# Altium schematic sheet EVK-NORA-W4_SCHEM_0003_USBhub_FTDI.SchDoc
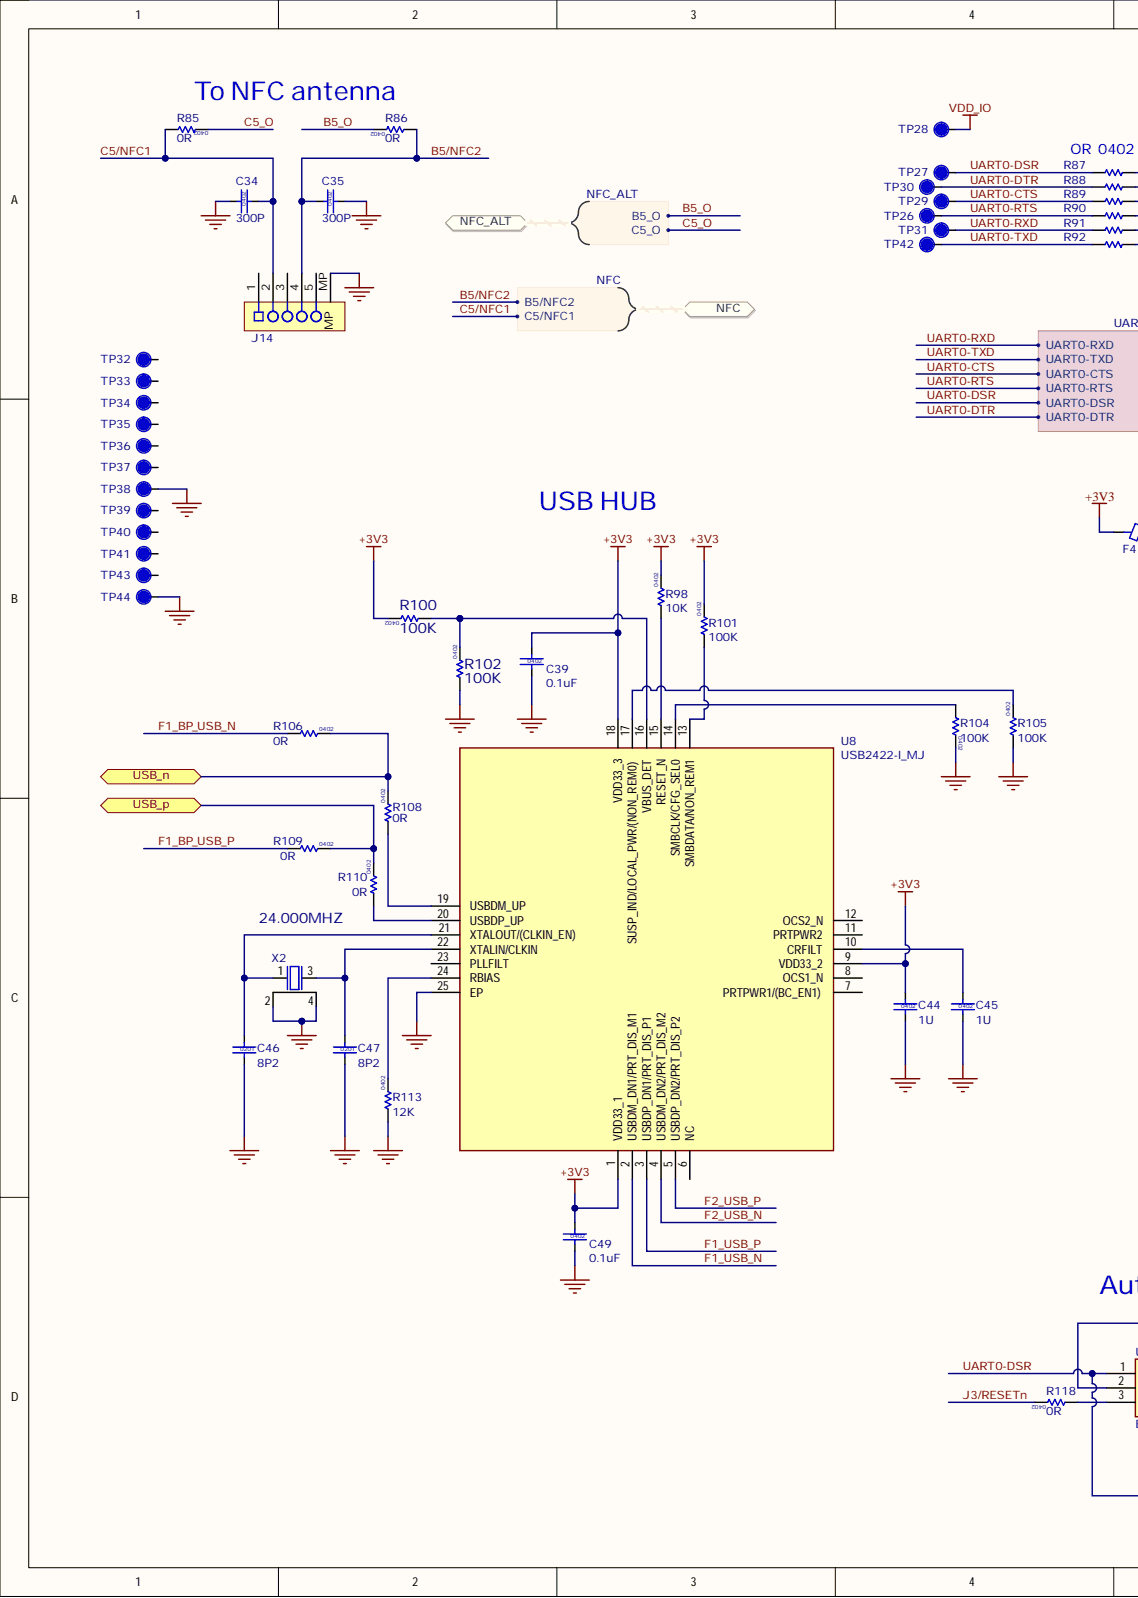
[diagram p0: EVK-NORA-W4_SCHEM_0003_USBhub_FTDI.SchDoc, left]
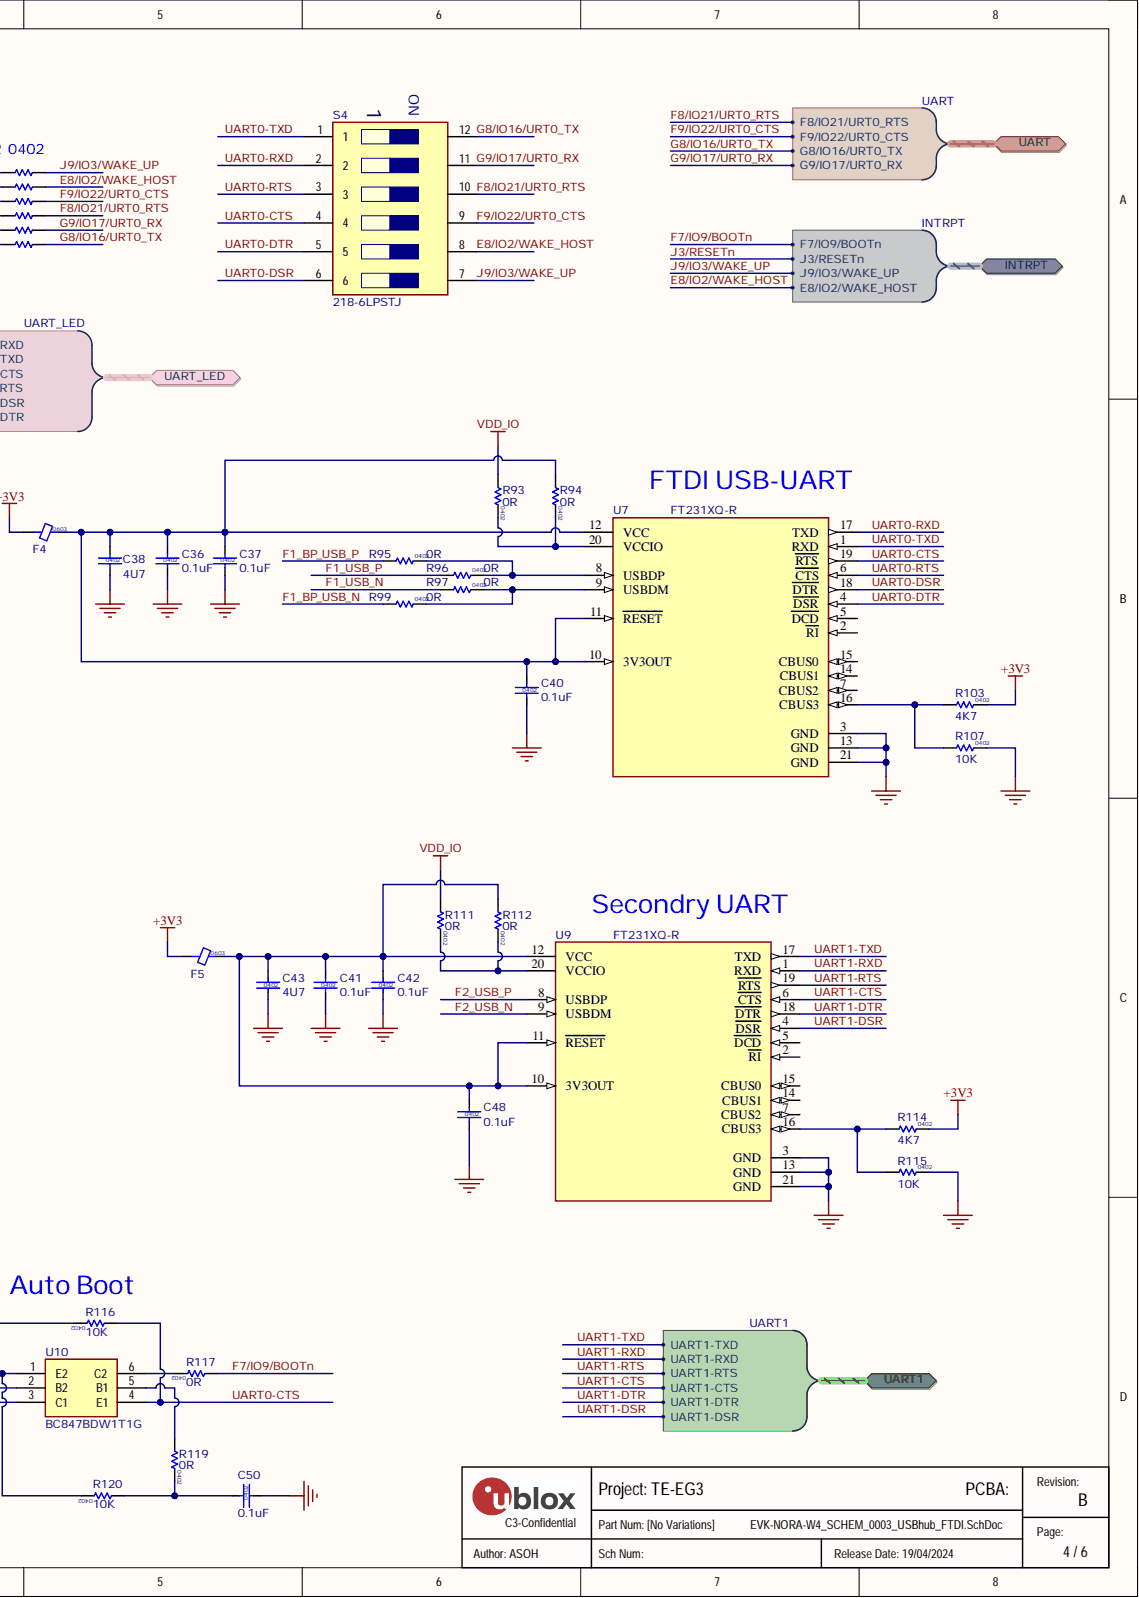
[diagram p1: EVK-NORA-W4_SCHEM_0003_USBhub_FTDI.SchDoc, right]

## Parts
C34  value 300P  Size: 0402  [Cap 0402 HD]  (hidden comment: UBXH01-0000594300P)
C35  value 300P  Size: 0402  [Cap 0402 HD]  (hidden comment: UBXH01-0000594300P)
C36  value 0.1uF  Size: 0402  [Cap 0402 HD]  (hidden comment: 120-000160.1uF)
C37  value 0.1uF  Size: 0402  [Cap 0402 HD]  (hidden comment: 120-000160.1uF)
C38  value 4U7  Size: 0402  [Cap 0402 HD]  (hidden comment: UBXH01-00002434U7)
C39  value 0.1uF  Size: 0402  [Cap 0402 HD]  (hidden comment: 120-000160.1uF)
C40  value 0.1uF  Size: 0402  [Cap 0402 HD]  (hidden comment: 120-000160.1uF)
C41  value 0.1uF  Size: 0402  [Cap 0402 HD]  (hidden comment: 120-000160.1uF)
C42  value 0.1uF  Size: 0402  [Cap 0402 HD]  (hidden comment: 120-000160.1uF)
C43  value 4U7  Size: 0402  [Cap 0402 HD]  (hidden comment: UBXH01-00002434U7)
C44  value 1U  Size: 0402  [Cap 0402 HD]  (hidden comment: UBXH01-00002481U)
C45  value 1U  Size: 0402  [Cap 0402 HD]  (hidden comment: UBXH01-00002481U)
C46  value 8P2  Size: 0201  [Cap 0201 HD]  (hidden comment: UBXH01-00130028P2)
C47  value 8P2  Size: 0201  [Cap 0201 HD]  (hidden comment: UBXH01-00130028P2)
C48  value 0.1uF  Size: 0402  [Cap 0402 HD]  (hidden comment: 120-000160.1uF)
C49  value 0.1uF  Size: 0402  [Cap 0402 HD]  (hidden comment: 120-000160.1uF)
C50  value 0.1uF  Size: 0402  [Cap 0402 HD]  (hidden comment: 120-000160.1uF)
F4  Size: 0603  [IND_0603_1750Lx950Wx1050H_600x800Pad_800G_FLX]  (hidden comment: UBXH08-0000001)
F5  Size: 0603  [IND_0603_1750Lx950Wx1050H_600x800Pad_800G_FLX]  (hidden comment: UBXH08-0000001)
J14  UBXH04-0000798  [AVX/Kyocera - 046284005001846]  (value not drawn)
R85  value 0R  Size: 0402  [RES 0402 HD]  (hidden comment: UBXH18-00030020R)
R86  value 0R  Size: 0402  [RES 0402 HD]  (hidden comment: UBXH18-00030020R)
R87  Size: 0402  [RES 0402 HD]  (hidden comment: UBXH18-00030020R)
R88  UBXH18-00030020R  [RES 0402 HD]  (value not drawn)
R89  UBXH18-00030020R  [RES 0402 HD]  (value not drawn)
R90  UBXH18-00030020R  [RES 0402 HD]  (value not drawn)
R91  UBXH18-00030020R  [RES 0402 HD]  (value not drawn)
R92  UBXH18-00030020R  [RES 0402 HD]  (value not drawn)
R93  value 0R  Size: 0402  [RES 0402 HD]  (hidden comment: UBXH18-00030020R)
R94  value 0R  Size: 0402  [RES 0402 HD]  (hidden comment: UBXH18-00030020R)
R95  value 0R  Size: 0402  [RES 0402 HD]  (hidden comment: UBXH18-00030020R)
R96  value 0R  Size: 0402  [RES 0402 HD]  (hidden comment: UBXH18-00030020R)
R97  value 0R  Size: 0402  [RES 0402 HD]  (hidden comment: UBXH18-00030020R)
R98  value 10K  Size: 0402  [RES 0402 HD]  (hidden comment: UBXH18-000006510K)
R99  value 0R  Size: 0402  [RES 0402 HD]  (hidden comment: UBXH18-00030020R)
R100  value 100K  Size: 0402  [RES 0402 HD]  (hidden comment: UBXH18-0000005100K)
R101  value 100K  Size: 0402  [RES 0402 HD]  (hidden comment: UBXH18-0000005100K)
R102  value 100K  Size: 0402  [RES 0402 HD]  (hidden comment: UBXH18-0000005100K)
R103  value 4K7  Size: 0402  [RES_0402_1100Lx600Wx400H_500x600Pad_400G_FLX]  (hidden comment: UBXH18-00080654K7)
R104  value 100K  Size: 0402  [RES 0402 HD]  (hidden comment: UBXH18-0000005100K)
R105  value 100K  Size: 0402  [RES 0402 HD]  (hidden comment: UBXH18-0000005100K)
R106  value 0R  Size: 0402  [RES 0402 HD]  (hidden comment: UBXH18-00030020R)
R107  value 10K  Size: 0402  [RES_0402_1100Lx600Wx400H_500x600Pad_400G_FLX]  (hidden comment: UBXH18-000807310K)
R108  value 0R  Size: 0402  [RES 0402 HD]  (hidden comment: UBXH18-00030020R)
R109  value 0R  Size: 0402  [RES 0402 HD]  (hidden comment: UBXH18-00030020R)
R110  value 0R  Size: 0402  [RES 0402 HD]  (hidden comment: UBXH18-00030020R)
R111  value 0R  Size: 0402  [RES 0402 HD]  (hidden comment: UBXH18-00030020R)
R112  value 0R  Size: 0402  [RES 0402 HD]  (hidden comment: UBXH18-00030020R)
R113  value 12K  Size: 0402  [RES_0402_1100Lx600Wx400H_500x600Pad_400G_FLX]  (hidden comment: UBXH18-000013312K)
R114  value 4K7  Size: 0402  [RES_0402_1100Lx600Wx400H_500x600Pad_400G_FLX]  (hidden comment: UBXH18-00080654K7)
R115  value 10K  Size: 0402  [RES_0402_1100Lx600Wx400H_500x600Pad_400G_FLX]  (hidden comment: UBXH18-000807310K)
R116  value 10K  Size: 0402  [RES 0402 HD]  (hidden comment: UBXH18-000006510K)
R117  value 0R  Size: 0402  [RES 0402 HD]  (hidden comment: UBXH18-00030020R)
R118  value 0R  Size: 0402  [RES 0402 HD]  (hidden comment: UBXH18-00030020R)
R119  value 0R  Size: 0402  [RES 0402 HD]  (hidden comment: UBXH18-00030020R)
R120  value 10K  Size: 0402  [RES 0402 HD]  (hidden comment: UBXH18-000006510K)
S4  218-6LPSTJ  [2186LPSTJ]
TP26  TP  [TP10R]  (no BOM)
TP27  TP  [TP10R]  (no BOM)
TP28  TP  [TP10R]  (no BOM)
TP29  TP  [TP10R]  (no BOM)
TP30  TP  [TP10R]  (no BOM)
TP31  TP  [TP10R]  (no BOM)
TP32  TP  [TP10R]  (no BOM)
TP33  TP  [TP10R]  (no BOM)
TP34  TP  [TP10R]  (no BOM)
TP35  TP  [TP10R]  (no BOM)
TP36  TP  [TP10R]  (no BOM)
TP37  TP  [TP10R]  (no BOM)
TP38  TP  [TP10R]  (no BOM)
TP39  TP  [TP10R]  (no BOM)
TP40  TP  [TP10R]  (no BOM)
TP41  TP  [TP10R]  (no BOM)
TP42  TP  [TP10R]  (no BOM)
TP43  TP  [TP10R]  (no BOM)
TP44  TP  [TP10R]  (no BOM)
U7  FT231XQ-R  [QFN_5x5_FT231XQ]
U8  USB2422-I_MJ  [QFN50P400X400X100-25N-D]
U9  FT231XQ-R  [QFN_5x5_FT231XQ]
U10  BC847BDW1T1G  [SOT65P210X110-6N]
X2  value 24.000MHZ  [XTAL_2016_HD]  (hidden comment: UBXH21-0000066)

## Ports
INTRPT, INTRPT.E8/IO2/WAKE_HOST, INTRPT.F7/IO9/BOOTn, INTRPT.J3/RESETn, INTRPT.J9/IO3/WAKE_UP, NFC, NFC.B5/NFC2, NFC.C5/NFC1, NFC_ALT, NFC_ALT.B5_O, NFC_ALT.C5_O, UART, UART1, UART1.UART1-CTS, UART1.UART1-DSR, UART1.UART1-DTR, UART1.UART1-RTS, UART1.UART1-RXD, UART1.UART1-TXD, UART.F8/IO21/URT0_RTS, UART.F9/IO22/URT0_CTS, UART.G8/IO16/URT0_TX, UART.G9/IO17/URT0_RX, UART_LED, UART_LED.UART0-CTS, UART_LED.UART0-DSR, UART_LED.UART0-DTR, UART_LED.UART0-RTS, UART_LED.UART0-RXD, UART_LED.UART0-TXD, USB_n, USB_p

## Nets
+3V3: C39.1 C44.1 C49.1 F4.1 F5.1 R98.2 R100.2 R101.2 R103.2 R114.2 U8.1(VDD33_1) U8.9(VDD33_2) U8.18(VDD33_3)
GND: C34.1 C35.2 C36.2 C37.2 C38.2 C39.2 C40.2 C41.2 C42.2 C43.2 C44.2 C45.2 C46.2 C47.2 C48.2 C49.2 C50.2 J14.MP R102.1 R104.2 R105.1 R107.2 R113.1 R115.2 TP38.1 TP44.1 U7.3(GND) U7.13(GND) U7.21(GND) U8.25(EP) U9.3(GND) U9.13(GND) U9.21(GND) X2.2 X2.4
VDD_IO: R93.1 R111.1 TP28.1
B5/NFC2: C35.1 J14.4 R86.1 ; port NFC
B5_O: R86.2 ; port NFC_ALT
C5/NFC1: C34.2 J14.2 R85.2 ; port NFC
C5_O: R85.1 ; port NFC_ALT
E8/IO2/WAKE_HOST: R88.2 S4.8 ; port INTRPT
F1_BP_USB_N: R99.1 R106.1
F1_BP_USB_P: R95.1 R109.1
F1_USB_N: R97.1 U8.2(USBDM_DN1/PRT_DIS_M1)
F1_USB_P: R96.1 U8.3(USBDP_DN1/PRT_DIS_P1)
F2_USB_N: U8.4(USBDM_DN2/PRT_DIS_M2) U9.9(USBDM)
F2_USB_P: U8.5(USBDP_DN2/PRT_DIS_P2) U9.8(USBDP)
F7/IO9/BOOTn: R117.1 ; port INTRPT
F8/IO21/URT0_RTS: R90.2 S4.10 ; port UART
F9/IO22/URT0_CTS: R89.2 S4.9 ; port UART
G8/IO16/URT0_TX: R92.2 S4.12 ; port UART
G9/IO17/URT0_RX: R91.2 S4.11 ; port UART
J3/RESETn: R118.2 ; port INTRPT
J9/IO3/WAKE_UP: R87.2 S4.7 ; port INTRPT
UART0-CTS: R89.1 R116.1 S4.4 TP29.1 U7.19(RTS) U10.4(E1) ; port UART_LED
UART0-DSR: R87.1 R120.2 S4.6 TP27.1 U7.18(DTR) U10.1(E2) ; port UART_LED
UART0-DTR: R88.1 S4.5 TP30.1 U7.4(DSR) ; port UART_LED
UART0-RTS: R90.1 S4.3 TP26.1 U7.6(CTS) ; port UART_LED
UART0-RXD: R91.1 S4.2 TP31.1 U7.17(TXD) ; port UART_LED
UART0-TXD: R92.1 S4.1 TP42.1 U7.1(RXD) ; port UART_LED
UART1-CTS: U9.6(CTS) ; port UART1
UART1-DSR: U9.4(DSR) ; port UART1
UART1-DTR: U9.18(DTR) ; port UART1
UART1-RTS: U9.19(RTS) ; port UART1
UART1-RXD: U9.1(RXD) ; port UART1
UART1-TXD: U9.17(TXD) ; port UART1
USB_n: R106.2 R108.2 ; port USB_n
USB_p: R109.2 R110.2 ; port USB_p
NetC36_1: C36.1 C37.1 C38.1 C40.1 F4.2 R94.1 U7.10(3V3OUT) U7.11(RESET) U7.12(VCC)
NetC41_1: C41.1 C42.1 C43.1 C48.1 F5.2 R112.1 U9.10(3V3OUT) U9.11(RESET) U9.12(VCC)
NetC45_1: C45.1 U8.10(CRFILT)
NetC46_1: C46.1 U8.21(XTALOUT/(CLKIN_EN)) X2.1
NetC47_1: C47.1 U8.22(XTALIN/CLKIN) X2.3
NetC50_1: C50.1 R119.2 R120.1
NetR93_2: R93.2 R94.2 U7.20(VCCIO)
NetR95_2: R95.2 R96.2 U7.8(USBDP)
NetR97_2: R97.2 R99.2 U7.9(USBDM)
NetR98_1: R98.1 U8.15(RESET_N)
NetR100_1: R100.1 R102.2 U8.16(VBUS_DET)
NetR101_1: R101.1 U8.13(SMBDATA/NON_REM1)
NetR103_1: R103.1 R107.1 U7.16(CBUS3)
NetR104_1: R104.1 U8.14(SMBCLK/CFG_SEL0)
NetR105_2: R105.2 U8.17(SUSP_IND/LOCAL_PWR/(NON_REM0))
NetR108_1: R108.1 U8.19(USBDM_UP)
NetR110_1: R110.1 U8.20(USBDP_UP)
NetR111_2: R111.2 R112.2 U9.20(VCCIO)
NetR113_2: R113.2 U8.24(RBIAS)
NetR114_1: R114.1 R115.1 U9.16(CBUS3)
NetR116_2: R116.2 U10.2(B2)
NetR117_2: R117.2 U10.6(C2)
NetR118_1: R118.1 U10.3(C1)
NetR119_1: R119.1 U10.5(B1)

Not connected: J14 1 3 5; TP32 1; TP33 1; TP34 1; TP35 1; TP36 1; TP37 1; TP39 1; TP40 1; TP41 1; TP43 1; U7 2(RI) 5(DCD) 7(CBUS2) 14(CBUS1) 15(CBUS0); U8 6(NC) 7(PRTPWR1/(BC_EN1)) 8(OCS1_N) 11(PRTPWR2) 12(OCS2_N) 23(PLLFILT); U9 2(RI) 5(DCD) 7(CBUS2) 14(CBUS1) 15(CBUS0)

## Library data of the parts
C34 C35 = UBXH01-0000594: CAP CER C0G 0402 300P 50V; Manufacturer: Murata Manufacturing Company, Ltd.; Manufacturer P/N: GRM1555C1H301JA01D; Database Table Name: Passive - Capacitor; Editor: eric.moog; Env: ROHS; Mfg P/N Released: No; Mfg P/N Split: 100; Mfg P/N Status: Active; Perferred: PREF; Permitted Use: Volume Products; Component Kind: Standard; Library Name: UBX_Parts_DB_Lib.DbLib; Max Operating Temp: 125°C; Max Voltage: 50V; Min Operating Temp: -55°C; Part Number: UBXH01-0000594; Part Type: Capacitor; RefDes: C; Signal Integrity: Cap Semi; Simulation: CAP; UBX Wiki: https://wiki.u-blox.com/bin/view/Operations/PartDetails?index=38856
C36 C37 C39-C42 C48-C50 = 120-00016: Cap, Ceramic 0.1uF 10V 10% X5R 0402; Manufacturer: Murata Electronics North America; Manufacturer P/N: GRM155R61A104KA01J; Part Name: CAP CER 0.1UF 10V 10% X5R 0402; Component Mounting Type: SMT; Database Table Name: Ceramic Capacitor; Item_Phase: Production; Tolerance: +/- 10%; Use in new designs: Yes; Arena Link: https://app.bom.com/a/GYHPV2L2L43KRMBK?v=spec.summary; Component Kind: Standard; Height: 0.55mm; Manufacturer 2: Yageo; Manufacturer P/N 2: CC0402KRX5R6BB104; Part Number: 120-00016; Part_Type: Ceramic Capacitor; Pins: 2; Revision: 0A; Signal Integrity: Cap Semi; Simulation: CAP; Supplier 1: Digi-Key; Supplier 2: Digi-Key; Supplier Part Number 1: 490-6297-1-ND; Supplier Part Number 2: 311-1336-1-ND; Temperature Coefficient: X5R; Voltage: 10V; Library Name: Arena_Parts_DB_Lib.DbLib
C38 C43 = UBXH01-0000243: CAP CER X5R 0402 4U7 20% 10V; Manufacturer: Murata Manufacturing Company, Ltd.; Manufacturer P/N: GRM155R61A475MEAA; Database Table Name: Passive - Capacitor; Editor: martin.pilik; Env: GREEN; Mfg P/N Released: Yes; Mfg P/N Split: 100; Mfg P/N Status: Active; Perferred: PREF; Permitted Use: Volume Products; Released by: fabrice.bret-dibat; Component Kind: Standard; Library Name: UBX_Parts_DB_Lib.DbLib; Max Operating Temp: 85°C; Max Voltage: 10V; Mfg P/N Datasheet: https://wiki.u-blox.com/bin/view/Generic/Docs/DocumentSummary?index=24302; Min Operating Temp: -40°C; Part Number: UBXH01-0000243; Part Type: Capacitor; RefDes: C; Release Data: 2021-05-10 12:57:47.325652; Signal Integrity: Cap Semi; Simulation: CAP; Tolerance: 20%; UBX Wiki: https://wiki.u-blox.com/bin/view/Operations/PartDetails?index=24303
C44 C45 = UBXH01-0000248: CAP CER X5R 0402 1U 10% 10V; Manufacturer: Murata Manufacturing Company, Ltd.; Manufacturer P/N: GRM155R61C105KA12; Database Table Name: Passive - Capacitor; Editor: michael.hein; Env: ROHS; Mfg P/N Released: Yes; Mfg P/N Split: 100; Mfg P/N Status: Active; Perferred: PREF; Permitted Use: Volume Products; Released by: igor.shevchenko; Component Kind: Standard; Library Name: UBX_Parts_DB_Lib.DbLib; Manufacturer 2: TDK Corporation; Manufacturer 3: Samsung Electro-Mechanics; Manufacturer P/N 2: C1005X5R1E105K050BC; Manufacturer P/N 3: CL05A105KA5NQNC; Max Operating Temp: 85°C; Max Voltage: 10V; Mfg P/N Datasheet: https://wiki.u-blox.com/bin/view/Generic/Docs/DocumentSummary?index=29581; Mfg P/N Datasheet 2: https://wiki.u-blox.com/bin/view/Generic/Docs/DocumentSummary?index=28058; Mfg P/N Datasheet 3: https://wiki.u-blox.com/bin/view/Generic/Docs/DocumentSummary?index=28056; Mfg P/N Released 2: Yes; Mfg P/N Released 3: Yes; Mfg P/N Split 2: 0; Mfg P/N Split 3: 0; Mfg P/N Status 2: Active; Mfg P/N Status 3: Active; Min Operating Temp: -40°C; Part Number: UBXH01-0000248; Part Type: Capacitor; RefDes: C; Release Data: 2016-03-16T11:26:35; Signal Integrity: Cap Semi; Simulation: CAP; Tolerance: 10%; UBX Wiki: https://wiki.u-blox.com/bin/view/Operations/PartDetails?index=24378
C46 C47 = UBXH01-0013002: CAP CER C0G 0201 8P2 +/-0.5P 25V; Manufacturer: Murata Manufacturing Company, Ltd.; Manufacturer P/N: GRM0335C1E8R2DA01; Database Table Name: Passive - Capacitor; Editor: roland.rust; Env: ROHS; Mfg P/N Released: Yes; Mfg P/N Split: 100; Mfg P/N Status: Active; Perferred: PREF; Permitted Use: Volume Products; Component Kind: Standard; Library Name: UBX_Parts_DB_Lib.DbLib; Manufacturer 2: Murata Manufacturing Company, Ltd.; Manufacturer P/N 2: GRM0335C1E8R2D; Max Voltage: 25V; Mfg P/N Released 2: Obsolete; Mfg P/N Split 2: 0; Mfg P/N Status 2: Active; Part Number: UBXH01-0013002; Part Type: Capacitor; RefDes: C; Release Data: 2009-03-23T10:34:14; Signal Integrity: Cap Semi; Simulation: CAP; Tolerance: +/-0.5P; UBX Wiki: https://wiki.u-blox.com/bin/view/Operations/PartDetails?index=5090; Released by: roland.rust
F4 F5 = UBXH08-0000001: FERRITE BEAD 0603 600 OHM AT 100MHZ 600OHM 500mA; Manufacturer: TDK Corporation; Manufacturer P/N: MMZ1608B601C; Database Table Name: Passive - Ferrite; Editor: daniel.hurni; Env: ROHS; Mfg P/N Released: Yes; Mfg P/N Split: 100; Mfg P/N Status: Active; Perferred: PREF; Permitted Use: Support Products; Released by: martin.frauchiger; Component Kind: Standard; Library Name: UBX_Parts_DB_Lib.DbLib; Max Current: 500mA; Max Operating Temp: 125°C; Mfg P/N Datasheet: https://wiki.u-blox.com/bin/view/Generic/Docs/DocumentSummary?index=7941; Min Operating Temp: -40°C; Part Number: UBXH08-0000001; Part Type: Ferrite; RefDes: FB; Release Data: 2009-12-02T10:18:36; UBX Wiki: https://wiki.u-blox.com/bin/view/Operations/PartDetails?index=7960; Value: 600OHM
J14 = UBXH04-0000798: 0.50MM PITCH SMD RA FFC FPC CONN 5 -PIN 50V 400mA; Manufacturer: AVX; Manufacturer 1: KYOCERA AVX; Manufacturer P/N: 046284005001846; Part Name: CON FFC/FPC 0.50mm 5Pos R/A; Part_Type: Connector; Component Mounting Type: SMT; Database Table Name: Electromechanical - Connector; Editor: eric.moog; Env: ROHS; Item_Phase: Preliminary; Keyed: No; Mfg P/N Split: 100; Mfg P/N Status: Active; Perferred: PREF; Permitted Use: Support Products; Pin Pitch: 0.5mm; Rows: 1; Use in new designs: Yes; Value: 046284005001846; Color: White; Component Kind: Standard; Connector Type: FPC; Height: 1.3mm; Length: 4.05mm; Library Name: UBX_Parts_DB_Lib.DbLib; Locking: No; Manufacturer Part Number 1: 046284005001846; Max Current: 400mA; Max Operating Temp: 85°C; Max Voltage: 50V; Mfg P/N Datasheet: https://wiki.u-blox.com/bin/view/Generic/Docs/DocumentSummary?index=38862; Mfg P/N Released: No; Min Operating Temp: -40°C; Part Number: UBXH04-0000798; Part Type: Connector; Pins: 5; RefDes: J; UBX Wiki: https://wiki.u-blox.com/bin/view/Operations/PartDetails?index=38822; Voltage: 50V; Width: 4.4mm
R85 R86 R93 R94 R111 R112 R117-R119 = UBXH18-0003002: RES THICK FILM CHIP 0402 0R 0 100mW; Manufacturer: KOA; Manufacturer P/N: RK73Z1ETTP; Database Table Name: Passive - Resistor; Editor: charlotte.biber; Env: ROHS; Mfg P/N Released: Yes; Mfg P/N Split: 100; Mfg P/N Status: NRND; Perferred: PREF; Permitted Use: Volume Products; Released by: peter.mueller; Tolerance: 0; Component Kind: Standard; Library Name: UBX_Parts_DB_Lib.DbLib; Manufacturer 2: YAGEO; Manufacturer P/N 2: 2322_705_91001_L; Max Power: 100mW; Mfg P/N Released 2: Yes; Mfg P/N Split 2: 0; Mfg P/N Status 2: NRND; Part Number: UBXH18-0003002; Part Type: Resistor; RefDes: R; Release Data: 2012-06-05 09:49:55.978616; Signal Integrity: Res; Simulation: RESISTOR; UBX Wiki: https://wiki.u-blox.com/bin/view/Operations/PartDetails?index=6611
R87-R92 R95-R97 R99 R106 R108-R110 = UBXH18-0003002: RES THICK FILM CHIP 0402 0R 0 100mW; Manufacturer: KOA; Manufacturer P/N: RK73Z1ETTD; Database Table Name: Passive - Resistor; Editor: charlotte.biber; Env: ROHS; Mfg P/N Released: Yes; Mfg P/N Split: 100; Mfg P/N Status: NRND; Perferred: PREF; Permitted Use: Volume Products; Released by: peter.mueller; Tolerance: 0; Component Kind: Standard; Library Name: UBX_Parts_DB_Lib.DbLib; Manufacturer 2: YAGEO; Manufacturer P/N 2: 2322_705_91001_L; Max Power: 100mW; Mfg P/N Released 2: Yes; Mfg P/N Split 2: 0; Mfg P/N Status 2: NRND; Part Number: UBXH18-0003002; Part Type: Resistor; RefDes: R; Release Data: 2012-06-05 09:49:55.978616; Signal Integrity: Res; Simulation: RESISTOR; UBX Wiki: https://wiki.u-blox.com/bin/view/Operations/PartDetails?index=6611
  R87: Value: 0R
  R88-R92: Size: 0402; Value: 0R
R98 R116 R120 = UBXH18-0000065: RES THICK FILM CHIP 0402 10K 1% 63mW; Manufacturer: KOA; Manufacturer P/N: RK73H1ETTP1002F; Database Table Name: Passive - Resistor; Editor: erian.bezhani; Env: ROHS; Mfg P/N Released: Yes; Mfg P/N Split: 100; Mfg P/N Status: Active; Perferred: PREF; Permitted Use: Volume Products; Released by: massimo.vlacci; Component Kind: Standard; Library Name: UBX_Parts_DB_Lib.DbLib; Max Power: 63mW; Mfg P/N Datasheet: https://wiki.u-blox.com/bin/view/Generic/Docs/DocumentSummary?index=8222; Part Number: UBXH18-0000065; Part Type: Resistor; RefDes: R; Release Data: 2012-06-08 18:02:21.521517; Signal Integrity: Res; Simulation: RESISTOR; Tolerance: 1%; UBX Wiki: https://wiki.u-blox.com/bin/view/Operations/PartDetails?index=16837
R100-R102 R104 R105 = UBXH18-0000005: RES THICK FILM CHIP 0402 100K 1% 63mW; Manufacturer: KOA; Manufacturer P/N: RK73H1ETTP1003F; Database Table Name: Passive - Resistor; Editor: dario.antonaz; Env: ROHS; Mfg P/N Released: Yes; Mfg P/N Split: 100; Mfg P/N Status: Active; Permitted Use: Volume Products; Released by: sandro.sestan; Component Kind: Standard; Library Name: UBX_Parts_DB_Lib.DbLib; Manufacturer 2: ROHM; Manufacturer P/N 2: MCR01MZPF1003; Max Power: 63mW; Mfg P/N Datasheet: https://wiki.u-blox.com/bin/view/Generic/Docs/DocumentSummary?index=8222; Mfg P/N Datasheet 2: https://wiki.u-blox.com/bin/view/Generic/Docs/DocumentSummary?index=8186; Mfg P/N Released 2: Yes; Mfg P/N Split 2: 0; Mfg P/N Status 2: Obsolete; Part Number: UBXH18-0000005; Part Type: Resistor; Perferred: N/A; RefDes: R; Release Data: 2009-11-30T11:05:22; Signal Integrity: Res; Simulation: RESISTOR; Tolerance: 1%; UBX Wiki: https://wiki.u-blox.com/bin/view/Operations/PartDetails?index=8114
R103 R114 = UBXH18-0008065: RES THICK FILM CHIP 0402 4K7 5% 100mW; Manufacturer: YAGEO; Manufacturer P/N: 2322_705_70472_L; Database Table Name: Passive - Resistor; Editor: martin.frauchiger; Env: ROHS; Mfg P/N Released: Yes; Mfg P/N Split: 100; Mfg P/N Status: Obsolete; Perferred: PREF; Permitted Use: Volume Products; Component Kind: Standard; Library Name: UBX_Parts_DB_Lib.DbLib; Manufacturer 2: KOA; Manufacturer P/N 2: RK73B1ETTD472J; Max Power: 100mW; Mfg P/N Released 2: Yes; Mfg P/N Split 2: 0; Mfg P/N Status 2: NRND; Part Number: UBXH18-0008065; Part Type: Resistor; RefDes: R; Release Data: 2005-08-19T10:42:45; Signal Integrity: Res; Simulation: RESISTOR; Tolerance: 5%; UBX Wiki: https://wiki.u-blox.com/bin/view/Operations/PartDetails?index=6969; Released by: martin.frauchiger
R107 R115 = UBXH18-0008073: RES THICK FILM CHIP 0402 10K 5% 100mW; Manufacturer: KOA; Manufacturer P/N: RK73B1ETTD103J; Database Table Name: Passive - Resistor; Editor: martin.frauchiger; Env: ROHS; Mfg P/N Released: Yes; Mfg P/N Split: 100; Mfg P/N Status: NRND; Perferred: PREF; Permitted Use: Volume Products; Component Kind: Standard; Library Name: UBX_Parts_DB_Lib.DbLib; Manufacturer 2: YAGEO; Manufacturer 3: YAGEO; Manufacturer P/N 2: RC0402JR-0710KL; Manufacturer P/N 3: 2322_705_87103_L; Max Power: 100mW; Mfg P/N Released 2: No; Mfg P/N Released 3: Yes; Mfg P/N Split 2: 0; Mfg P/N Split 3: 0; Mfg P/N Status 2: Active; Mfg P/N Status 3: Obsolete; Part Number: UBXH18-0008073; Part Type: Resistor; RefDes: R; Release Data: 2005-08-19T10:42:45; Signal Integrity: Res; Simulation: RESISTOR; Tolerance: 5%; UBX Wiki: https://wiki.u-blox.com/bin/view/Operations/PartDetails?index=6981; Released by: martin.frauchiger
R113 = UBXH18-0000133: RES THICK FILM CHIP 0402 12K 1% 62.5mW; Manufacturer: YAGEO; Manufacturer P/N: RT0402FRE0712KL; Database Table Name: Passive - Resistor; Editor: pierpaolo.arban; Env: ROHS; Mfg P/N Released: Yes; Mfg P/N Split: 100; Mfg P/N Status: Active; Perferred: PREF; Permitted Use: Volume Products; Released by: dario.antonaz; Component Kind: Standard; Library Name: UBX_Parts_DB_Lib.DbLib; Max Operating Temp: 155°C; Max Power: 62.5mW; Mfg P/N Datasheet: https://wiki.u-blox.com/bin/view/Generic/Docs/DocumentSummary?index=19276; Min Operating Temp: -55°C; Part Number: UBXH18-0000133; Part Type: Resistor; RefDes: R; Release Data: 2019-11-07T09:33:25; Signal Integrity: Res; Simulation: RESISTOR; Tolerance: 1%; UBX Wiki: https://wiki.u-blox.com/bin/view/Operations/PartDetails?index=20511
S4 = 218-6LPSTJ: Switch; Manufacturer_Name: CTS; Arrow Part Number: 218-6LPSTJ; Arrow Price/Stock: https://www.arrow.com/en/products/218-6lpstj/cts; Component Kind: Standard; Datasheet Link: https://www.ctscorp.com/wp-content/uploads/218.pdf; Height: 2.7mm; Library Name: SamacSys.SchLib; Manufacturer_Part_Number: 218-6LPSTJ; Mouser Part Number: 774-2186LPSTJ; Mouser Price/Stock: https://www.mouser.co.uk/ProductDetail/CTS-Electronic-Components/218-6LPSTJ?qs=hDoPxpGr7lflvoQJzlrOfA%3D%3D
TP26-TP44 = TP10R: Testpoint, Round 1.0mm Dia; Added By: Jeremy D; Database Table Name: Testpoints & Pads; Added Date: 2/1/2015; Checked Date: 2/5/2015; DNP: DNP; Height: 0; Part Number: TP10R; Size: 1.0mm; Checked By: Paul B; Library Name: LEGACY_Rigado_AltiumLib.DbLib
U7 U9 = UBXH10-0000054: FTDI USB TO SERIAL FULL UART INTERFACE QFN20; Manufacturer: FTDI Future Technology Devices International; Database Table Name: IC - Interface; Editor: adam.johansson; Env: ROHS; Mfg P/N Released: No; Mfg P/N Split: 100; Mfg P/N Status: Active; Perferred: PREF; Permitted Use: Support Products; Component Kind: Standard; Library Name: UBX_Parts_DB_Lib.DbLib; Manufacturer P/N: FT231XQ-R; Max Operating Temp: 85°C; Mfg P/N Datasheet: https://wiki.u-blox.com/bin/view/Generic/Docs/DocumentSummary?index=33707; Min Operating Temp: -40°C; Part Number: UBXH10-0000054; Part Type: Interface; RefDes: U; UBX Wiki: https://wiki.u-blox.com/bin/view/Operations/PartDetails?index=27258; Value: FT231XQ-R
U8 = USB2422-I_MJ: Integrated Circuit; Manufacturer_Name: Microchip; Manufacturer_Part_Number: USB2422-I/MJ; Arrow Part Number: USB2422-I/MJ; Arrow Price/Stock: https://www.arrow.com/en/products/usb2422-imj/microchip-technology?region=nac; Component Kind: Standard; Datasheet Link: https://datasheet.datasheetarchive.com/originals/distributors/DKDS-15/280029.pdf; Height: 1mm; Mouser Part Number: 579-USB2422-I/MJ; Mouser Price/Stock: https://www.mouser.co.uk/ProductDetail/Microchip-Technology-Atmel/USB2422-I-MJ?qs=eTPcRhULBzzm1uvzgv8YJg%3D%3D
U10 = BC847BDW1T1G: Integrated Circuit; Manufacturer_Name: onsemi; Arrow Part Number: BC847BDW1T1G; Arrow Price/Stock: https://www.arrow.com/en/products/bc847bdw1t1g/on-semiconductor?region=europe; Component Kind: Standard; Datasheet Link: http://www.onsemi.com/pub/Collateral/BC846BDW1T1-D.PDF; Height: 1.1mm; Manufacturer_Part_Number: BC847BDW1T1G; Mouser Part Number: 863-BC847BDW1T1G; Mouser Price/Stock: https://www.mouser.co.uk/ProductDetail/onsemi/BC847BDW1T1G?qs=vNc2DXHODiJIIkxW3oEo4A%3D%3D
X2 = UBXH21-0000066: CRYSTAL CL=8PF EPSON 2.0X1.6MM 24.000MHZ 30PPM; Manufacturer: Seiko Epson Corporation; Manufacturer P/N: Q22FA1280046700; Critical: Yes; Database Table Name: Passive - Crystal; Editor: adam.johansson; Env: ROHS; Mfg P/N Split: 100; Mfg P/N Status: Active; Perferred: PREF; Permitted Use: Volume Products; Released by: olof.viklund; Component Kind: Standard; Library Name: UBX_Parts_DB_Lib.DbLib; Manufacturer 2: Seiko Epson Corporation; Manufacturer P/N 2: Q22FA1280039800; Max Operating Temp: 85°C; Mfg P/N Datasheet: https://wiki.u-blox.com/bin/view/Generic/Docs/DocumentSummary?index=23017; Mfg P/N Datasheet 2: https://wiki.u-blox.com/bin/view/Generic/Docs/DocumentSummary?index=21965; Mfg P/N Released: Yes; Mfg P/N Released 2: Obsolete; Mfg P/N Split 2: 0; Mfg P/N Status 2: Obsolete; Min Operating Temp: -40°C; Part Number: UBXH21-0000066; Part Type: Crystal; RefDes: Y; Release Data: 2015-11-11T10:35:48; Tolerance: 30PPM; UBX Wiki: https://wiki.u-blox.com/bin/view/Operations/PartDetails?index=21963
